# Altium schematic sheet Safety_LightsSch.SchDoc(Safety_LightsSch)
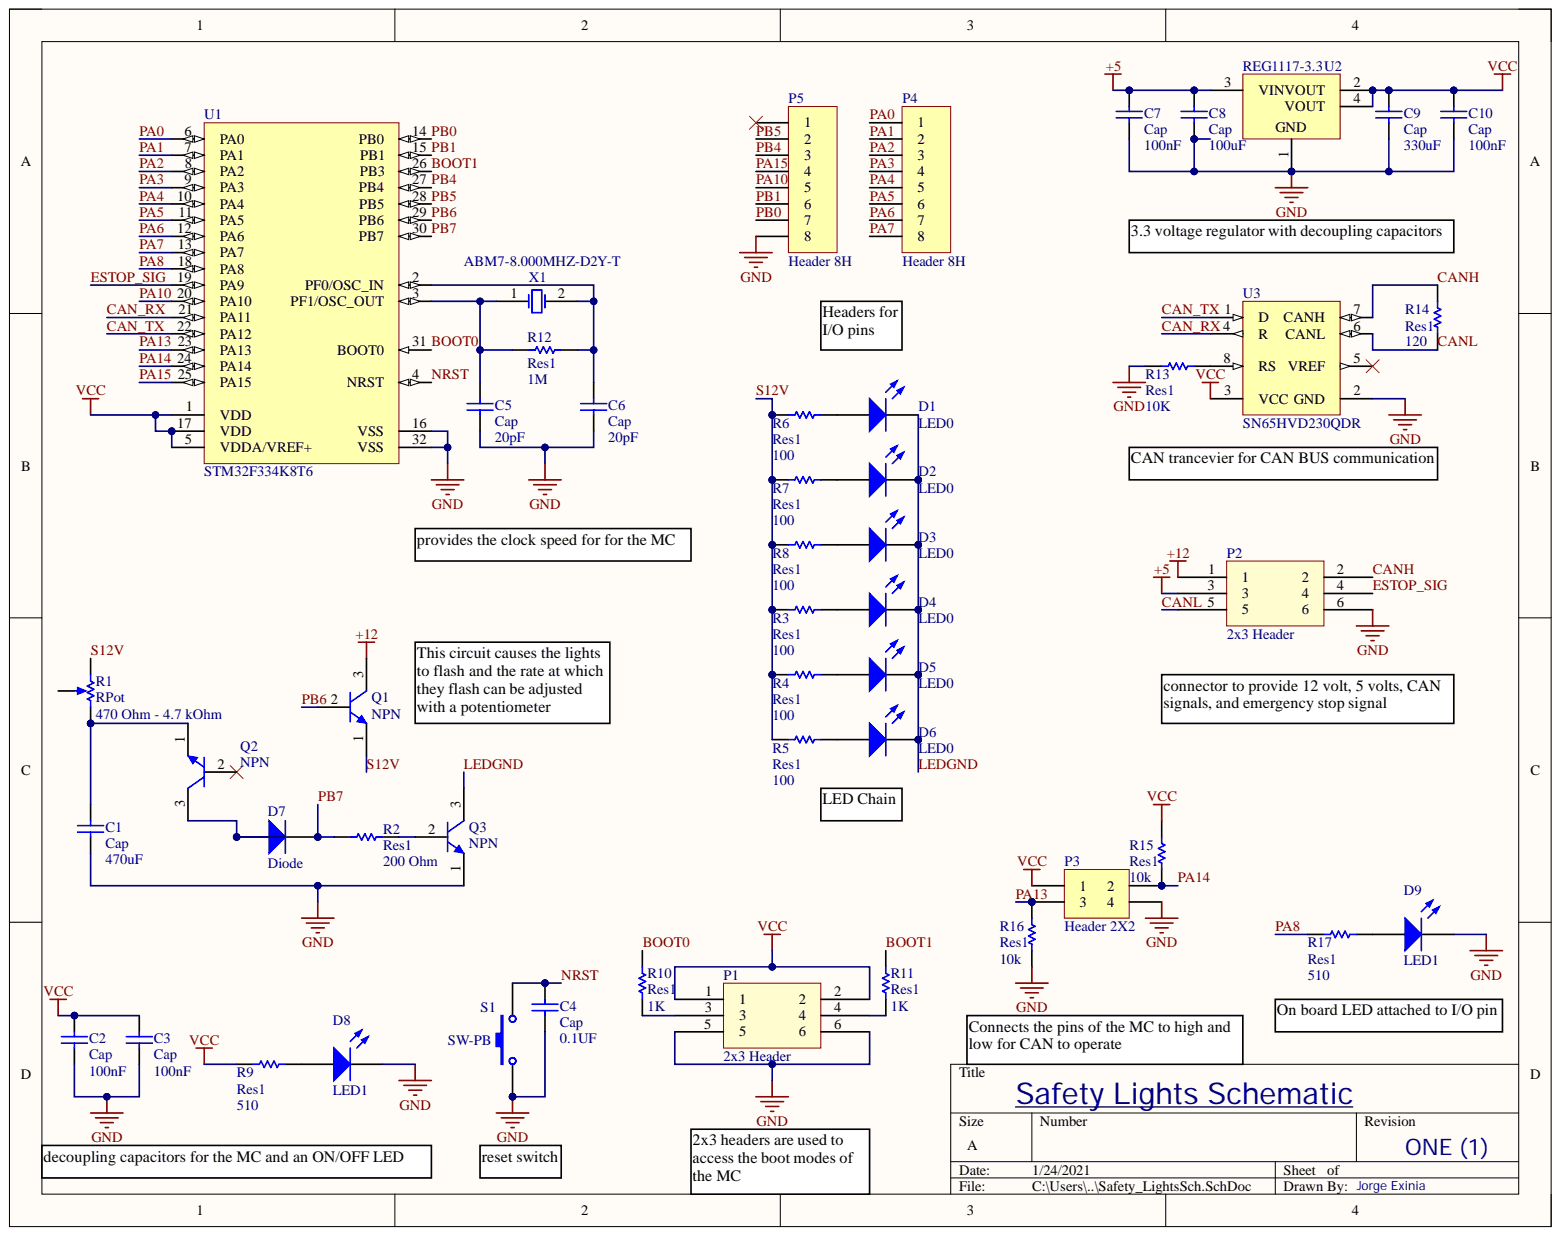
[diagram p0: Safety_LightsSch.SchDoc]

## Parts
C1  Cap  value 470uF  [6-0805_L]
C2  Cap  value 100nF  [6-0805_L]
C3  Cap  value 100nF  [6-0805_L]
C4  Cap  value 0.1UF  [6-0805_L]
C5  Cap  value 20pF  [6-0805_L]
C6  Cap  value 20pF  [6-0805_L]
C7  Cap  value 100nF  [6-0805_L]
C8  Cap  value 100uF  [6-0805_L]
C9  Cap  value 330uF  [6-0805_L]
C10  Cap  value 100nF  [6-0805_L]
D1  LED0  [XLAMP]
D2  LED0  [XLAMP]
D3  LED0  [XLAMP]
D4  LED0  [XLAMP]
D5  LED0  [XLAMP]
D6  LED0  [XLAMP]
D7  Diode  [6-0805_L]
D8  LED1  [6-0805_L]
D9  LED1  [6-0805_L]
P1  2x3 Header  [HDR2X3]
P2  2x3 Header  [Molex Connector]
P3  Header 2X2  [HDR2X2]
P4  Header 8H  [HDR1X8]
P5  Header 8H  [HDR1X8]
Q1  NPN  [TO-226-AA]
Q2  NPN  [TO-226-AA]
Q3  NPN  [TO-226-AA]
R1  RPot  value 470 Ohm - 4.7 kOhm  [Potentiometer]
R2  Res1  value 200 Ohm  [1608[0603]]
R3  Res1  value 100  [1608[0603]]
R4  Res1  value 100  [1608[0603]]
R5  Res1  value 100  [1608[0603]]
R6  Res1  [1608[0603]]
R7  Res1  value 100  [1608[0603]]
R8  Res1  value 100  [1608[0603]]
R9  Res1  value 510  [1608[0603]]
R10  Res1  value 1K  [1608[0603]]
R11  Res1  value 1K  [1608[0603]]
R12  Res1  value 1M  [1608[0603]]
R13  Res1  value 10K  [1608[0603]]
R14  Res1  value 120  [1608[0603]]
R15  Res1  value 10k  [1608[0603]]
R16  Res1  value 10k  [1608[0603]]
R17  Res1  value 510  [1608[0603]]
S1  SW-PB  [Push Switch 3x6x2.5]
U1  STM32F334K8T6  [STM-LQFP32_M]
U2  REG1117-3.3  [DCY0004A_M]
U3  SN65HVD230QDR  [PCA82C250]
X1  ABM7-8.000MHZ-D2Y-T  [ABRA-ABM7-2_V]

## Nets
+5: C7.2 C8.2 P2.3 U2.3(VIN)
+12: P2.1 Q1.3
GND: C1.1 C2.1 C3.1 C4.1 C5.2 C6.2 C7.1 C8.1 C9.1 C10.1 D8.2 D9.2 P1.5 P1.6 P2.6 P3.4 P5.8 Q3.1 R13.1 R16.1 S1.1 U1.16(VSS) U1.32(VSS) U2.1 U3.2(GND)
VCC: C2.2 C3.2 C9.2 C10.2 P1.1 P1.2 P3.1 R9.1 R15.2 U1.1(VDD) U1.5(VDDA/VREF+) U1.17(VDD) U2.2(VOUT) U2.4(VOUT) U3.3(VCC)
BOOT0: R10.2 U1.31(BOOT0)
BOOT1: R11.2 U1.26(PB3)
CAN_RX: U1.21(PA11) U3.4(R)
CAN_TX: U1.22(PA12) U3.1(D)
CANH: P2.2 R14.2 U3.7(CANH)
CANL: P2.5 R14.1 U3.6(CANL)
ESTOP_SIG: P2.4 U1.19(PA9)
LEDGND: D1.2 D2.2 D3.2 D4.2 D5.2 D6.2 Q3.3
NRST: C4.2 S1.2 U1.4(NRST)
PA0: P4.1 U1.6(PA0)
PA1: P4.2 U1.7(PA1)
PA2: P4.3 U1.8(PA2)
PA3: P4.4 U1.9(PA3)
PA4: P4.5 U1.10(PA4)
PA5: P4.6 U1.11(PA5)
PA6: P4.7 U1.12(PA6)
PA7: P4.8 U1.13(PA7)
PA8: R17.1 U1.18(PA8)
PA10: P5.5 U1.20(PA10)
PA13: P3.3 R16.2 U1.23(PA13)
PA14: P3.2 R15.1 U1.24(PA14)
PA15: P5.4 U1.25(PA15)
PB0: P5.7 U1.14(PB0)
PB1: P5.6 U1.15(PB1)
PB4: P5.3 U1.27(PB4)
PB5: P5.2 U1.28(PB5)
PB6: Q1.2 U1.29(PB6)
PB7: D7.2 R2.1 U1.30(PB7)
S12V: Q1.1 R1.1 R3.1 R4.1 R5.1 R6.1 R7.1 R8.1
NetC1_2: C1.2 Q2.1 R1.3
NetC5_1: C5.1 R12.1 U1.3(PF1/OSC_OUT) X1.1
NetC6_1: C6.1 R12.2 U1.2(PF0/OSC_IN) X1.2
NetD1_1: D1.1 R6.2
NetD2_1: D2.1 R7.2
NetD3_1: D3.1 R8.2
NetD4_1: D4.1 R3.2
NetD5_1: D5.1 R4.2
NetD6_1: D6.1 R5.2
NetD7_1: D7.1 Q2.3
NetD8_1: D8.1 R9.2
NetD9_1: D9.1 R17.2
NetP1_3: P1.3 R10.1
NetP1_4: P1.4 R11.1
NetQ3_2: Q3.2 R2.2
NetR13_2: R13.2 U3.8(RS)

Not connected: P5 1; Q2 2; R1 2; U3 5(VREF)

## Library data of the parts
C1-C10 = Cap: Capacitor; Component Kind: Standard; LatestRevisionDate: 17-Jul-2002; LatestRevisionNote: Re-released for DXP Platform.; Library Name: Miscellaneous Devices.IntLib; PackageDescription: Radial Cap, Thru-Hole; 2 Leads; 0.3 in Pin Spacing; PackageReference: RAD-0.3; Published: 8-Jun-2000; Signal Integrity: Cap; Simulation: CAP; Publisher: Altium Limited
D1-D6 = LED0: Typical INFRARED GaAs LED; Component Kind: Standard; LatestRevisionDate: 08-Jul-2005; LatestRevisionNote: Mech Layer 1 removed.; Library Name: Miscellaneous Devices.IntLib; PackageDescription: LED; 2 Leads; PackageReference: LED-0; Published: 8-Jun-2000; Simulation: LED0; Publisher: Altium Limited
  D1: Supplier 1: Mouser; Supplier Part Number 1: 941-XPGDWTBS00MDV
D7 = Diode: Default Diode; Code_JEDEC: DO-214-AB; Component Kind: Standard; LatestRevisionDate: 17-Jul-2002; LatestRevisionNote: Re-released for DXP Platform.; Library Name: Miscellaneous Devices.IntLib; PackageDescription: DO-214-AB/SMC; 2 C-Bend Leads; Body 7.9 x 5.9 mm, inc. leads (LxW); PackageReference: SMC; PackageVersion: Sep-1996; Published: 8-Jun-2000; Signal Integrity: Diode; Simulation: DIODE; Publisher: Altium Limited
D8 D9 = LED1: Typical RED GaAs LED; Component Kind: Standard; LatestRevisionDate: 08-Jul-2005; LatestRevisionNote: Mech Layer 1 removed.; Library Name: Miscellaneous Devices.IntLib; PackageDescription: LED; 2 Leads; PackageReference: LED-1; Published: 8-Jun-2000; Simulation: LED1; Publisher: Altium Limited
P3 = Header 2X2: Header, 2-Pin, Dual row; Component Kind: Standard; LatestRevisionDate: 17-Jul-2002; LatestRevisionNote: Re-released for DXP Platform.; Library Name: Miscellaneous Connectors.IntLib; Signal Integrity: Connector; Publisher: Altium Limited
P4 P5 = Header 8H: Header, 8-Pin, Right Angle; Component Kind: Standard; LatestRevisionDate: 17-Jul-2002; LatestRevisionNote: Re-released for DXP Platform.; Library Name: Miscellaneous Connectors.IntLib; Signal Integrity: Connector; Publisher: Altium Limited
Q1-Q3 = NPN: NPN Bipolar Transistor; Code_JEDEC: TO-226-AA; Component Kind: Standard; LatestRevisionDate: 17-Jul-2002; LatestRevisionNote: Re-released for DXP Platform.; Library Name: Miscellaneous Devices.IntLib; PackageDescription: TO, Flat Index; 3 In-Line, Axial Leads; Body Dia. 4.8mm; Leads 0.55 x 0.51 mm (max); PackageReference: TO-226-AA; Published: 8-Jun-2000; Signal Integrity: NPN; Simulation: NPN; Publisher: Altium Limited
R1 = RPot: Potentiometer (https://www.mouser.com/ProductDetail/BI-Technologies-TT-Electronics/P162G100QRA20A103/?qs=OlC7AqGiEDnwGBx8%2F2PEtQ%3D%3D); Set Position: 0.5; Sim Note: Enter the Resistance value and the set point into parameter fields.; Value: 470 Ohm - 4.7 kOhm; Component Kind: Standard; LatestRevisionDate: 17-Jul-2002; LatestRevisionNote: Re-released for DXP Platform.; Library Name: Miscellaneous Devices.IntLib; PackageDescription: Variable Resistor, Thru-Hole; 3 Leads; PackageReference: VR5; Published: 8-Jun-2000; Simulation: POT; Publisher: Altium Limited
R2 = Res1: Resistor; Value: 200 Ohm; Component Kind: Standard; LatestRevisionDate: 17-Jul-2002; LatestRevisionNote: Re-released for DXP Platform.; Library Name: Miscellaneous Devices.IntLib; PackageDescription: Axial Device, Thru-Hole; 2 Leads; 0.3 in Pin Spacing; PackageReference: AXIAL-0.3; Published: 8-Jun-2000; Signal Integrity: Res; Simulation: RESISTOR; Publisher: Altium Limited
R3-R5 R7-R14 R16 R17 = Res1: Resistor; Component Kind: Standard; LatestRevisionDate: 17-Jul-2002; LatestRevisionNote: Re-released for DXP Platform.; Library Name: Miscellaneous Devices.IntLib; PackageDescription: Axial Device, Thru-Hole; 2 Leads; 0.3 in Pin Spacing; PackageReference: AXIAL-0.3; Published: 8-Jun-2000; Signal Integrity: Res; Simulation: RESISTOR; Publisher: Altium Limited
R6 = Res1: Resistor; Value: 100; Component Kind: Standard; LatestRevisionDate: 17-Jul-2002; LatestRevisionNote: Re-released for DXP Platform.; Library Name: Miscellaneous Devices.IntLib; PackageDescription: Axial Device, Thru-Hole; 2 Leads; 0.3 in Pin Spacing; PackageReference: AXIAL-0.3; Published: 8-Jun-2000; Signal Integrity: Res; Simulation: RESISTOR; Publisher: Altium Limited
R15 = Res1: Resistor; Value: 10k; Component Kind: Standard; LatestRevisionDate: 17-Jul-2002; LatestRevisionNote: Re-released for DXP Platform.; Library Name: Miscellaneous Devices.IntLib; PackageDescription: Axial Device, Thru-Hole; 2 Leads; 0.3 in Pin Spacing; PackageReference: AXIAL-0.3; Published: 8-Jun-2000; Signal Integrity: Res; Simulation: RESISTOR; Publisher: Altium Limited
S1 = SW-PB: Switch; Component Kind: Standard; LatestRevisionDate: 17-Jul-2002; LatestRevisionNote: Re-released for DXP Platform.; Library Name: Miscellaneous Devices.IntLib; PackageDescription: Switch; 2 Leads; PackageReference: SPST-2; Published: 24-Mar-1999; Publisher: Altium Limited
U1 = CMP-0537-00074-1: ARM Cortex-M4 32-bit MCU, 64 KB Flash, 16 KB Internal RAM, 25 I/Os, 32-Pin LQFP, -40 to 85 degC, Tray; D/A Converter: 3; FLASH Size (Prog) (kB): 64; I/Os (High Current): 25; Internal RAM Size (kB): 16; Operating Frequency(F) (Processor speed) (MHz): 72; Supply Voltage(Vcc) min (V): 2; Component Kind: Standard; ComponentLink1Description: Manufacturer URL; ComponentLink1URL: http://www.st.com/; ComponentLink2Description: Datasheet; ComponentLink2URL: http://www.st.com/st-web-ui/static/active/en/resource/technical/document/datasheet/DM00097745.pdf; Core: ARM Cortex-M4; DatasheetVersion: Rev. 4; Library Name: Altium Content Vault; Mounting Technology: Surface Mount; Package Description: 32-Pin Low Profile Quad Flat Package, 7 x 7 mm Body, 0.8 mm Pitch; Package Reference: LQFP32; Packing: Tray
U2 = CMP-0395-00549-2: Single Output LDO, 800 mA, Fixed 3.3 V Output, 3.8 to 15 V Input, with Internal Current Limiting, 4-pin SOT-223 (DCY), -40 to 125 degC, Green (RoHS & no Sb/Br); Manufacturer: Texas Instruments; Fixed Output Options(V): 5; Iout(Max)(A): 0.8; Iq(Typ)(mA): 4; Rating: Catalog; SubFamily: Single Channel LDO; Vdo(Typ)(mV): 1100; Vin(Max)(V): 15; Vin(Min)(V): 3.8; Vout Adj(Max)(V): 3.3; Code_JEDEC: TO-261; Component Kind: Standard; ComponentLink1Description: Manufacturer URL; ComponentLink1URL: http://www.ti.com/; ComponentLink2Description: Datasheet; ComponentLink2URL: http://www.ti.com/general/docs/lit/getliterature.tsp?genericPartNumber=REG1117-33&fileType=pdf; ComponentLink3Description: Package Specification; ComponentLink3URL: http://www.ti.com/litv/pdf/mpds094a; DatasheetVersion: SBVS001D; Eco-Plan: Green (RoHS & no Sb/Br); Library Name: Altium Content Vault; Mounting Technology: Surface Mount; PackageDescription: 4-Pin Plastic Small Outline, Body 3.5 x 6.5 mm, Pitch 2.3 mm; PackageReference: DCY0004A; PackageVersion: 4202506/B; PartNumber: REG1117-3.3; Vout Adj(Min)(V): 3.3
U3 = CMP-0315-00033-2: Automotive Catalog 3.3 V CAN Transceiver, 17 mA, 3.3 V, -40 to 125 degC, 8-pin SOIC (D), Green (RoHS & no Sb/Br); Manufacturer: Texas Instruments; Drivers Per Package: 1; ICC(Max)(mA): 17; Supply Voltage(s)(V): 3.3; Transient Pulse Protection(V): -25 to 25; Code_JEDEC: MS-012AA; Component Kind: Standard; ComponentLink1Description: Datasheet; ComponentLink1URL: http://www.ti.com/lit/ds/symlink/sn65hvd230.pdf; DatasheetVersion: SLOS346K; Eco-Plan: Green (RoHS & no Sb/Br); Isolated: No; Library Name: Altium Content Vault; Mounting Technology: Surface Mount; PackageDescription: 8-Pin Plastic Small Outline Integrated Circuit, 4.9 x 3.9 mm, Pitch 1.27 mm Pitch; PackageReference: D0008A; PackageVersion: revM, Jun-2011; PartNumber: SN65HVD230QDR; Rating: Catalog; Receivers Per Package: 1; SubFamily: CAN
X1 = CMP-2000-05034-1: Microprocessor Crystal, 8 MHz, 18 PF, -40 to 85 degC, 2-Pin SMD, RoHS, Tape and Reel; Manufacturer: Abracon; 3D Model: ABM7.pdf    ABM7.stp; Catalog Drawings: ABM7SeriesTop    ABM7SeriesSide    ABM7SeriesBottom; ESR (Equivalent Series Resistance): 100Ohm; Featured Product: ABM7andABM11Crystals; Frequency: 8MHz; Frequency Stability: ±30ppm; Frequency Tolerance: ±20ppm; Load Capacitance: 18pF; Online Catalog: ABM7Series; Operating Mode: Fundamental; Other Names: 535-9831-2  ABM7-8.000MHZ-D-2-Y-T  ABM78000MHZD2YT; Category: CrystalsandOscillators; Component Kind: Standard; Datasheet URL: https://datasheet.ciiva.com/1357/1718755-1357277.pdf; Datasheet Version: Dec-17; Family: Crystals; Height: 0.055"(1.40mm); Library Name: Altium Content Vault; Manufacturer URL: http://www.abracon.com/; Mounting Type: SurfaceMount; Operating Temperature: -40degC~85degC; Package / Case: 2-SMD; Package Description: 2-Pin Surface Mount Device, Body 6 x 3.5 mm; Package Reference: ABM7; Packaging: Tape&Reel(TR); RoHS Information: RoHS2/REACHCompliance; Series: ABM7; Size / Dimension: 0.236"Lx0.138"W(6.00mmx3.50mm); Standard Package: 1,000; Type: MHzCrystal
P1 P2 = 2x3 Header: Component Kind: Standard; Library Name: Schlib1.SchLib
